# Altium schematic sheet Sheet1.SchDoc
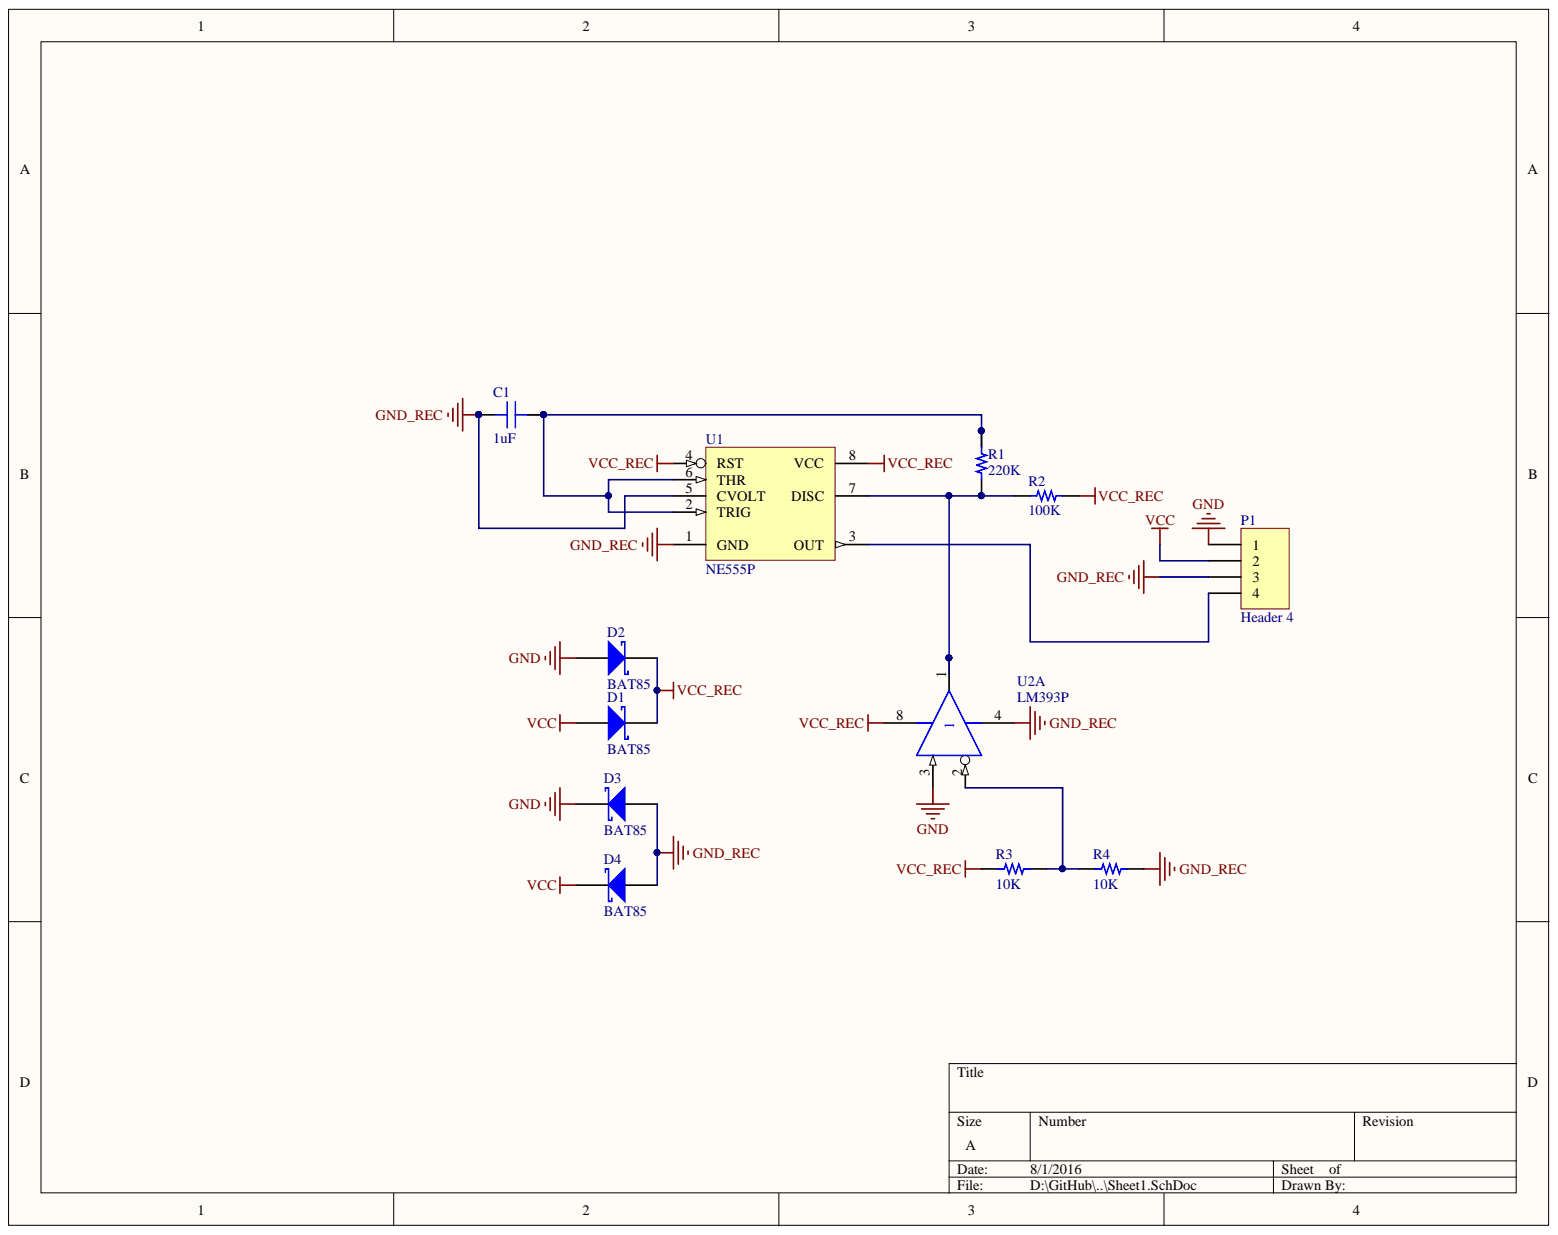
[diagram p0: Sheet1.SchDoc]

## Parts
C1  1uF  [CAP 0805/2012]
D1  BAT85  [SOD68]
D2  BAT85  [SOD68]
D3  BAT85  [SOD68]
D4  BAT85  [SOD68]
P1  Header 4  [HDR1X4]
R1  220K  [RES 0805/2012]
R2  100K  [RES 0805/2012]
R3  10K  [RES 0805/2012]
R4  10K  [RES 0805/2012]
U1  NE555P  [P008]
U2  LM393P  [P008]  (part A)

## Nets
GND: D2.1 D3.2 P1.1 U2.3
GND_REC: C1.1 D3.1 D4.1 P1.3 R4.1 U1.1(GND) U1.5(CVOLT) U2.4
VCC: D1.1 D4.2 P1.2
VCC_REC: D1.2 D2.2 R2.1 R3.2 U1.4(RST) U1.8(VCC) U2.8
NetC1_2: C1.2 R1.2 U1.2(TRIG) U1.6(THR)
NetP1_4: P1.4 U1.3(OUT)
NetR1_1: R1.1 R2.2 U1.7(DISC) U2.1
NetR3_1: R3.1 R4.2 U2.2

## Library data of the parts
C1 = CC0805KRX7R9BB103: CAP CER 10000PF 50V X7R 0805; Manufacturer: Yageo; Database Table Name: Capacitor - Ceramic; TemperatureRange: -55C ~ 125C; Tolerance: 10%; Component Kind: Standard; ComponentLink1Description: Datasheet; ComponentLink1URL: http://www.yageo.com/documents/recent/UPY-GPHC_X7R_6.3V-to-50V-v12.pdf; ComponentLink2Description: Digi-Key listing; ComponentLink2URL: http://digikey.com/product-detail/en/CC0805KRX7R9BB103/311-1136-2-ND/302870; Device Package: 0805 (2012 Metric); Library Name: Database_Libs1.DbLib; PartId: 5694; Part Number: CC0805KRX7R9BB103; Price: 0.0081; Supplier 1: Digi-Key; Supplier Part Number 1: 311-1136-2-ND; TemperatureCoefficient: X7R; Type: -; Value: 10000pF; Voltage: 50V
D1-D4 = BAT85: Schottky Barrier Diode; Code_JEDEC: DO-204-AG; Component Kind: Standard; ComponentLink1Description: Manufacturer Link; ComponentLink1URL: http://www.semiconductors.philips.com/; ComponentLink2Description: Datasheet; ComponentLink2URL: http://www.semiconductors.philips.com/acrobat/datasheets/BAT85_4.pdf; DatasheetVersion: 25-May-2000; Library Name: Philips Discrete Diode - Schottky.IntLib; PackageDescription: Diode, Axial; Body 3 x 1.6 mm (LxDia. max), Lead Dia. 0.55 mm (max); PackageReference: SOD68; PackageVersion: May-2000; Published: 17-Sep-2003; Signal Integrity: BAT85; Simulation: BAT85; Publisher: Altium Limited
P1 = Header 4: Header, 4-Pin; Component Kind: Standard; LatestRevisionDate: 17-Jul-2002; LatestRevisionNote: Re-released for DXP Platform.; Library Name: Miscellaneous Connectors.IntLib; Signal Integrity: Connector; Publisher: Altium Limited
R1-R4 = ERJ-6ENF49R9V: RES SMD 49.9 OHM 1% 1/8W 0805; Manufacturer: Panasonic Electronic Components; Database Table Name: Resistor - Chip; PowerRating: 0.125W, 1/8W; TemperatureCoefficient: 100ppm/C; TemperatureRange: -55C ~ 155C; Value: 49.9; Component Kind: Standard; ComponentLink1Description: Datasheet; ComponentLink1URL: http://industrial.panasonic.com/www-cgi/jvcr13pz.cgi?E+PZ+3+AOA0002+ERJ6ENF49R9V+7+WW; ComponentLink2Description: Digi-Key listing; ComponentLink2URL: http://digikey.com/product-detail/en/ERJ-6ENF49R9V/P49.9CTR-ND/111027; Device Package: 0805 (2012 Metric); Library Name: Database_Libs1.DbLib; PartId: 1960; Part Number: ERJ-6ENF49R9V; Price: 0.0073; Supplier 1: Digi-Key; Supplier Part Number 1: P49.9CTR-ND; Tolerance: 1%; Type: Thick Film
U1 = NE555P: Precision Timer; Code_JEDEC: MO-001-AN; Component Kind: Standard; ComponentLink1Description: Manufacturer Link; ComponentLink1URL: http://www.ti.com/; ComponentLink2Description: Datasheet; ComponentLink2URL: http://www-s.ti.com/sc/ds/ne555.pdf; DatasheetVersion: Feb-1992; LatestRevisionDate: 04-Mar-2005; LatestRevisionNote: Stylized 3D Model Added.; Library Name: TI Analog Timer Circuit.IntLib; PackageDescription: DIP; 8 Leads; Row Spacing 7.62 mm; Pitch 2.54 mm; PackageReference: P008; PackageVersion: Jun-1999; PCB3D: P008; Published: 16-Jan-2001; Publisher: Altium Limited
U2 = LM393P: Dual Differential Comparator; Code_JEDEC: MO-001-AN; Component Kind: Standard; ComponentLink1Description: Manufacturer Link; ComponentLink1URL: http://www.ti.com/; ComponentLink2Description: Datasheet; ComponentLink2URL: http://www-s.ti.com/sc/ds/lm193.pdf; DatasheetVersion: Nov-1988; LatestRevisionDate: 04-Mar-2005; LatestRevisionNote: Stylized 3D Model Added.; Library Name: TI Logic Comparator.IntLib; PackageDescription: DIP; 8 Leads; Row Spacing 7.62 mm; Pitch 2.54 mm; PackageReference: P008; PackageVersion: Jun-1999; PCB3D: P008; Published: 15-Apr-2002; Publisher: Altium Limited
